# Altium schematic sheet Communications.SchDoc(Communications)
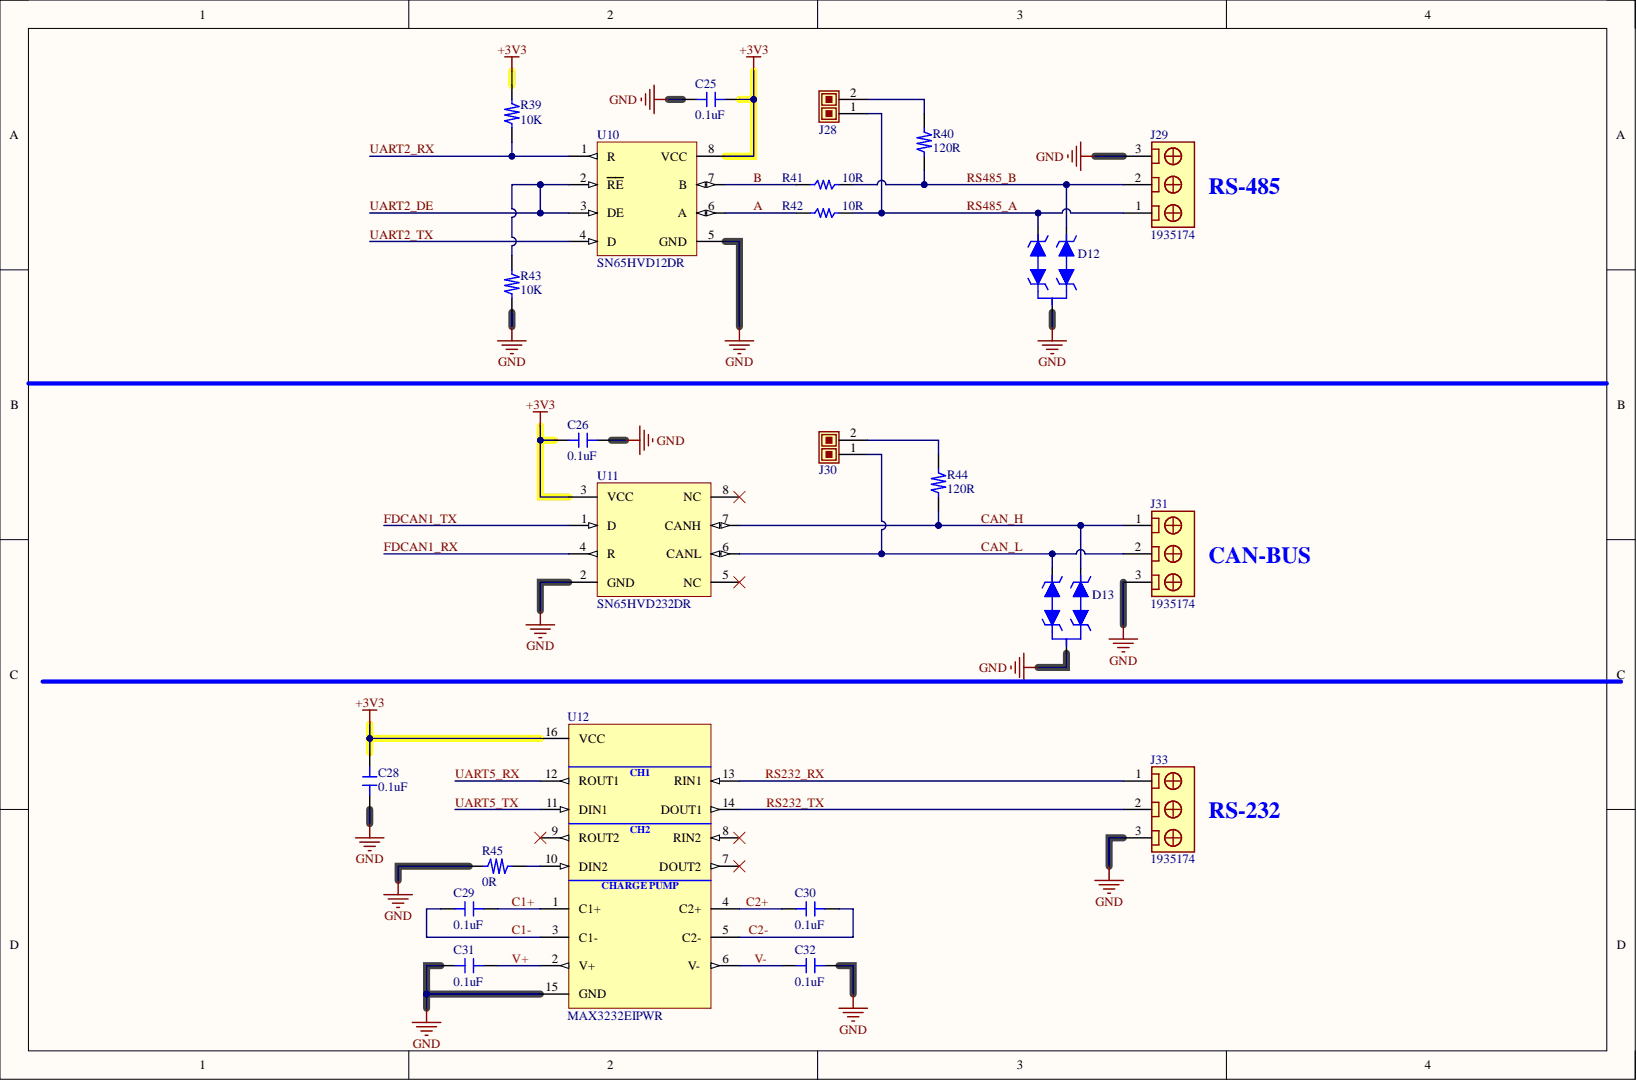
[diagram p0: Communications.SchDoc]

## Parts
C25  value 0.1uF  [CAP0603]  (hidden comment: GRM188R72A104KA35D)
C26  value 0.1uF  [CAP0603]  (hidden comment: GRM188R72A104KA35D)
C28  value 0.1uF  [CAP0603]  (hidden comment: GRM188R72A104KA35D)
C29  value 0.1uF  [CAP0603]  (hidden comment: GRM188R72A104KA35D)
C30  value 0.1uF  [CAP0603]  (hidden comment: GRM188R72A104KA35D)
C31  value 0.1uF  [CAP0603]  (hidden comment: GRM188R72A104KA35D)
C32  value 0.1uF  [CAP0603]  (hidden comment: GRM188R72A104KA35D)
D12  CDSOT23-SM712  [SOT23]  (value not drawn)
D13  PESD2CANFD27L-UX  [SOT23]  (value not drawn)
J28  68001-202HLF  [2P-2.54mm-MalePins]  (value not drawn)
J29  1935174  [1935174]
J30  68001-202HLF  [2P-2.54mm-MalePins]  (value not drawn)
J31  1935174  [1935174]
J33  1935174  [1935174]
R39  value 10K  [RES0603]  (hidden comment: RC0603FR-0710KL)
R40  value 120R  [RES0603]  (hidden comment: RC0603FR-07120RL)
R41  value 10R  [RES0603]  (hidden comment: RC0603FR-0710RL)
R42  value 10R  [RES0603]  (hidden comment: RC0603FR-0710RL)
R43  value 10K  [RES0603]  (hidden comment: RC0603FR-0710KL)
R44  value 120R  [RES0603]  (hidden comment: RC0603FR-07120RL)
R45  value 0R  [RES0603]  (hidden comment: RC0603FR-070RL)
U10  SN65HVD12DR  [SOIC-8]
U11  SN65HVD232DR  [SOIC-8]
U12  MAX3232EIPWR  [TSSOP-16]

## Nets
+3V3: C25.2 C26.2 C28.1 R39.1 U10.8(VCC) U11.3(VCC) U12.16(VCC)
GND: C25.1 C26.1 C28.2 C31.1 C32.2 D12.3 D13.3 J29.3 J31.3 J33.3 R43.2 R45.2 U10.5(GND) U11.2(GND) U12.15(GND)
A: R42.2 U10.6(A)
B: R41.2 U10.7(B)
C1+: C29.2 U12.1(C1+)
C1-: C29.1 U12.3(C1-)
C2+: C30.1 U12.4(C2+)
C2-: C30.2 U12.5(C2-)
CAN_H: D13.2 J31.1 R44.2 U11.7(CANH)
CAN_L: D13.1 J30.1 J31.2 U11.6(CANL)
FDCAN1_RX: U11.4(R)
FDCAN1_TX: U11.1(D)
RS232_RX: J33.1 U12.13(RIN1)
RS232_TX: J33.2 U12.14(DOUT1)
RS485_A: D12.1 J28.1 J29.1 R42.1
RS485_B: D12.2 J29.2 R40.2 R41.1
UART2_DE: R43.1 U10.2(RE) U10.3(DE)
UART2_RX: R39.2 U10.1(R)
UART2_TX: U10.4(D)
UART5_RX: U12.12(ROUT1)
UART5_TX: U12.11(DIN1)
V+: C31.2 U12.2(V+)
V-: C32.1 U12.6(V-)
NetJ28_2: J28.2 R40.1
NetJ30_2: J30.2 R44.1
NetR45_1: R45.1 U12.10(DIN2)

Not connected: U11 5(NC) 8(NC); U12 7(DOUT2) 8(RIN2) 9(ROUT2)

## Library data of the parts
C25 C26 C28-C32 = GRM188R72A104KA35D: 0.1 µF ±10% 100V Ceramic Capacitor X7R 0603 (1608 Metric); Manufacturer: Murata Electronics; Component Kind: Standard; ComponentLink1Description: Datasheet; ComponentLink1URL: https://search.murata.co.jp/Ceramy/image/img/A01X/G101/ENG/GRM188R72A104KA35-01.pdf; ComponentLink2Description: DigiKey Link; ComponentLink2URL: https://www.digikey.com/en/products/detail/murata-electronics/GRM188R72A104KA35D/702549; ComponentLink3Description: LCSC Link; ComponentLink3URL: https://lcsc.com/product-detail/Multilayer-Ceramic-Capacitors-MLCC-SMD-SMT_muRata-GRM188R72A104KA35D_C77058.html?s_z=n_GRM188R72A104KA35D; DigiKey PN: 490-3285-1-ND; LCSC PN: C77058; Library Name: 01-Capacitors_0603.IntLib; MPN: GRM188R72A104KA35D; Package: 0603; Temp Coefficient: X7R; Tolerance: ±10%; Voltage Rating: 100V
D12 = CDSOT23-SM712: RS-485 , 26V, 14V Clamp 17A (8/20µs) Ipp Tvs Diode Surface Mount SOT-23-3; Manufacturer: Bourns Inc.; Component Kind: Standard; ComponentLink1Description: Datasheet; ComponentLink1URL: https://www.bourns.com/docs/Product-Datasheets/CDSOT23-SM712.pdf; ComponentLink2Description: DigiKey Link; ComponentLink2URL: https://www.digikey.com/en/products/detail/bourns-inc/CDSOT23-SM712/1630592; ComponentLink3Description: LCSC Link; ComponentLink3URL: https://www.lcsc.com/product-detail/C404012.html?s_z=n_CDSOT23-SM712; DigiKey PN: CDSOT23-SM712CT-ND; LCSC PN: C404012; Library Name: 12-Protection-TVS_Diodes.IntLib; MPN: CDSOT23-SM712; Package: SOT23
D13 = PESD2CANFD27L-UX: CAN, CAN FD, TVS Clamp 4A (8/20µs) Ipp Tvs Diode Surface Mount SC-70; Manufacturer: Nexperia USA Inc.; Component Kind: Standard; ComponentLink1Description: Datasheet; ComponentLink1URL: https://assets.nexperia.com/documents/data-sheet/PESD2CANFD27L-U.pdf; ComponentLink2Description: DigiKey Link; ComponentLink2URL: https://www.digikey.com/en/products/detail/nexperia-usa-inc/PESD2CANFD27L-UX/12808359; ComponentLink3Description: LCSC Link; ComponentLink3URL: https://www.lcsc.com/product-detail/C3713903.html?s_z=n_PESD2CANFD27L-UX; DigiKey PN: 1727-PESD2CANFD27L-UXCT-ND; LCSC PN: C3713903; Library Name: 12-Protection-TVS_Diodes.IntLib; MPN: PESD2CANFD27L-UX; Package: SOT23
J28 J30 = 68001-202HLF: Connector Header Through Hole 2 position 0.100" (2.54mm); Manufacturer: Amphenol ICC (FCI); Component Kind: Standard; ComponentLink1Description: Datasheet; ComponentLink1URL: https://www.waldom.com/upload/parts/datasheets/mf-fci/fci-68001-202hlf.pdf; ComponentLink2Description: DigiKey Link; ComponentLink2URL: https://www.digikey.com/en/products/detail/amphenol-cs-fci/68001-202HLF/2023308?s=N4IgTCBcDaIGwA4AMSCMBaMSwAkAyAYiALoC%2BQA; ComponentLink3Description: LCSC Link; ComponentLink3URL: https://www.lcsc.com/product-detail/C2931354.html?s_z=n_68001-202HLF; DigiKey PN: 609-3469-ND; LCSC PN: C2931354; Library Name: 11-Connectors_2.54mm.IntLib; MPN: 68001-202HLF
J29 J31 J33 = 1935174: TB 5.00mm 3POS TH 18A, 300V, 12-26 AWG Wire; Manufacturer: Phoenix Contact; Component Kind: Standard; ComponentLink1Description: Datasheet; ComponentLink1URL: https://mm.digikey.com/Volume0/opasdata/d220001/medias/docus/1123/1935174.pdf; ComponentLink2Description: DigiKey Link; ComponentLink2URL: https://www.digikey.com/en/products/detail/phoenix-contact/1935174/568615; ComponentLink3Description: LCSC Link; ComponentLink3URL: https://www.lcsc.com/product-detail/C3816891.html; DigiKey PN: 277-1578-ND; LCSC PN: C3816891; Library Name: 11-Connectors_5.00mm.IntLib; MPN: 1935174; Series: COMBICON PT
R39 R43 = RC0603FR-0710KL: 10 kOhms ±1% 0.1W, 1/10W Chip Resistor 0603 (1608 Metric) Moisture Resistant Thick Film; Manufacturer: YAGEO; Component Kind: Standard; ComponentLink1Description: Datasheet; ComponentLink1URL: https://www.yageo.com/upload/media/product/products/datasheet/rchip/PYu-RC_Group_51_RoHS_L_12.pdf; ComponentLink2Description: DigiKey Link; ComponentLink2URL: https://www.digikey.com/en/products/detail/yageo/RC0603FR-0710KL/726880?s=N4IgTCBcDaIEoGEAMA2JBmAYnAtEg7AIxIDSAMiALoC%2BQA; ComponentLink3Description: LCSC Link; ComponentLink3URL: https://lcsc.com/product-detail/Chip-Resistor-Surface-Mount_YAGEO-RC0603FR-0710KL_C98220.html?s_z=n_RC0603FR-0710KL; DigiKey PN: 311-10.0KHRCT-ND; LCSC PN: C98220; Library Name: 00-Resistors_0603.IntLib; MPN: RC0603FR-0710KL; Package: 0603
R40 R44 = RC0603FR-07120RL: 120 Ohms ±1% 0.1W, 1/10W Chip Resistor 0603 (1608 Metric) Moisture Resistant Thick Film; Manufacturer: YAGEO; Component Kind: Standard; ComponentLink1Description: Datasheet; ComponentLink1URL: https://www.yageo.com/upload/media/product/products/datasheet/rchip/PYu-RC_Group_51_RoHS_L_12.pdf; ComponentLink2Description: DigiKey Link; ComponentLink2URL: https://www.digikey.com/en/products/detail/yageo/RC0603FR-07120RL/726920?s=N4IgTCBcDaIEoGEAMA2JBmAYnAtEg7AIxhJwAyIAugL5A; ComponentLink3Description: LCSC Link; ComponentLink3URL: https://www.lcsc.com/product-detail/C114640.html?s_z=n_RC0603FR-07120RL; DigiKey PN: 311-120HRCT-ND; LCSC PN: C114640; Library Name: 00-Resistors_0603.IntLib; MPN: RC0603FR-07120RL; Package: 0603
R41 R42 = RC0603FR-0710RL: 10 Ohms ±1% 0.1W, 1/10W Chip Resistor 0603 (1608 Metric) Moisture Resistant Thick Film; Manufacturer: YAGEO; Component Kind: Standard; ComponentLink1Description: Datasheet; ComponentLink1URL: https://www.yageo.com/upload/media/product/products/datasheet/rchip/PYu-RC_Group_51_RoHS_L_12.pdf; ComponentLink2Description: DigiKey Link; ComponentLink2URL: https://www.digikey.com/en/products/detail/yageo/RC0603FR-0710RL/726879?s=N4IgTCBcDaIEoGEAMA2JBmAYnAtEg7AIxJwAyIAugL5A; ComponentLink3Description: LCSC Link; ComponentLink3URL: https://www.lcsc.com/product-detail/C109318.html?s_z=n_RC0603FR-0710RL; DigiKey PN: 311-10.0HRCT-ND; LCSC PN: C109318; Library Name: 00-Resistors_0603.IntLib; MPN: RC0603FR-0710RL; Package: 0603
R45 = RC0603FR-070RL: 0 Ohms Jumper Chip Resistor 0603 (1608 Metric) Moisture Resistant Thick Film; Manufacturer: YAGEO; Component Kind: Standard; ComponentLink1Description: Datasheet; ComponentLink1URL: https://www.yageo.com/upload/media/product/products/datasheet/rchip/PYu-RC_Group_51_RoHS_L_12.pdf; ComponentLink2Description: DigiKey Link; ComponentLink2URL: https://www.digikey.com/en/products/detail/yageo/RC0603FR-070RL/1304008?s=N4IgTCBcDaIEoGEAMA2JBmAYnAtEg7EnADIgC6AvkA; ComponentLink3Description: LCSC Link; ComponentLink3URL: https://lcsc.com/product-detail/Chip-Resistor-Surface-Mount_YAGEO-RC0603FR-070RL_C100044.html?s_z=n_RC0603FR-070RL; DigiKey PN: 311-0.0HRCT-ND; LCSC PN: C100044; Library Name: 00-Resistors_0603.IntLib; MPN: RC0603FR-070RL; Package: 0603
U10 = SN65HVD12DR: 1/1 Transceiver Half RS422, RS485 8-SOIC; Manufacturer: Texas Instruments; Component Kind: Standard; ComponentLink1Description: Datasheet; ComponentLink1URL: https://www.ti.com/general/docs/suppproductinfo.tsp?distId=10&gotoUrl=https%3A%2F%2Fwww.ti.com%2Flit%2Fgpn%2Fsn65hvd11; ComponentLink2Description: DigiKey Link; ComponentLink2URL: https://www.digikey.com/en/products/detail/texas-instruments/SN65HVD12DR/1574483; ComponentLink3Description: LCSC Link; ComponentLink3URL: https://www.lcsc.com/product-detail/C58890.html?s_z=n_SN65HVD12DR; DigiKey PN: 296-26338-1-ND; LCSC PN: C58890; Library Name: 14-Interface_ICs.IntLib; MPN: SN65HVD12DR; Package: SOIC-8
U11 = SN65HVD232DR: 1/1 Transceiver Half CANbus 8-SOIC; Manufacturer: Texas Instruments; Component Kind: Standard; ComponentLink1Description: Datasheet; ComponentLink1URL: https://www.ti.com/general/docs/suppproductinfo.tsp?distId=10&gotoUrl=https%3A%2F%2Fwww.ti.com%2Flit%2Fgpn%2Fsn65hvd230; ComponentLink2Description: DigiKey Link; ComponentLink2URL: https://www.digikey.com/en/products/detail/texas-instruments/SN65HVD232DR/1574505; ComponentLink3Description: LCSC Link; ComponentLink3URL: https://www.lcsc.com/product-detail/C30530.html?s_z=n_SN65HVD232DR; DigiKey PN: 296-26344-1-ND; LCSC PN: C30530; Library Name: 14-Interface_ICs.IntLib; MPN: SN65HVD232DR; Package: SOIC-8
U12 = MAX3232EIPWR: 2/2 Transceiver Full RS232 16-TSSOP; Manufacturer: Texas Instruments; Component Kind: Standard; ComponentLink1Description: Datasheet; ComponentLink1URL: https://www.ti.com/lit/ds/symlink/max3232e-q1.pdf?ts=1736232244138; ComponentLink2Description: DigiKey Link; ComponentLink2URL: https://www.digikey.com/en/products/detail/texas-instruments/MAX3232EIPWR/968150; ComponentLink3Description: LCSC Link; ComponentLink3URL: https://www.lcsc.com/product-detail/C406863.html?s_z=n_MAX3232EIPWR; DigiKey PN: 296-19436-1-ND; LCSC PN: C406863; Library Name: 14-Interface_ICs.IntLib; MPN: MAX3232EIPWR; Package: TSSOP-16
